# Altium schematic sheet ultrasonic_rx.SchDoc
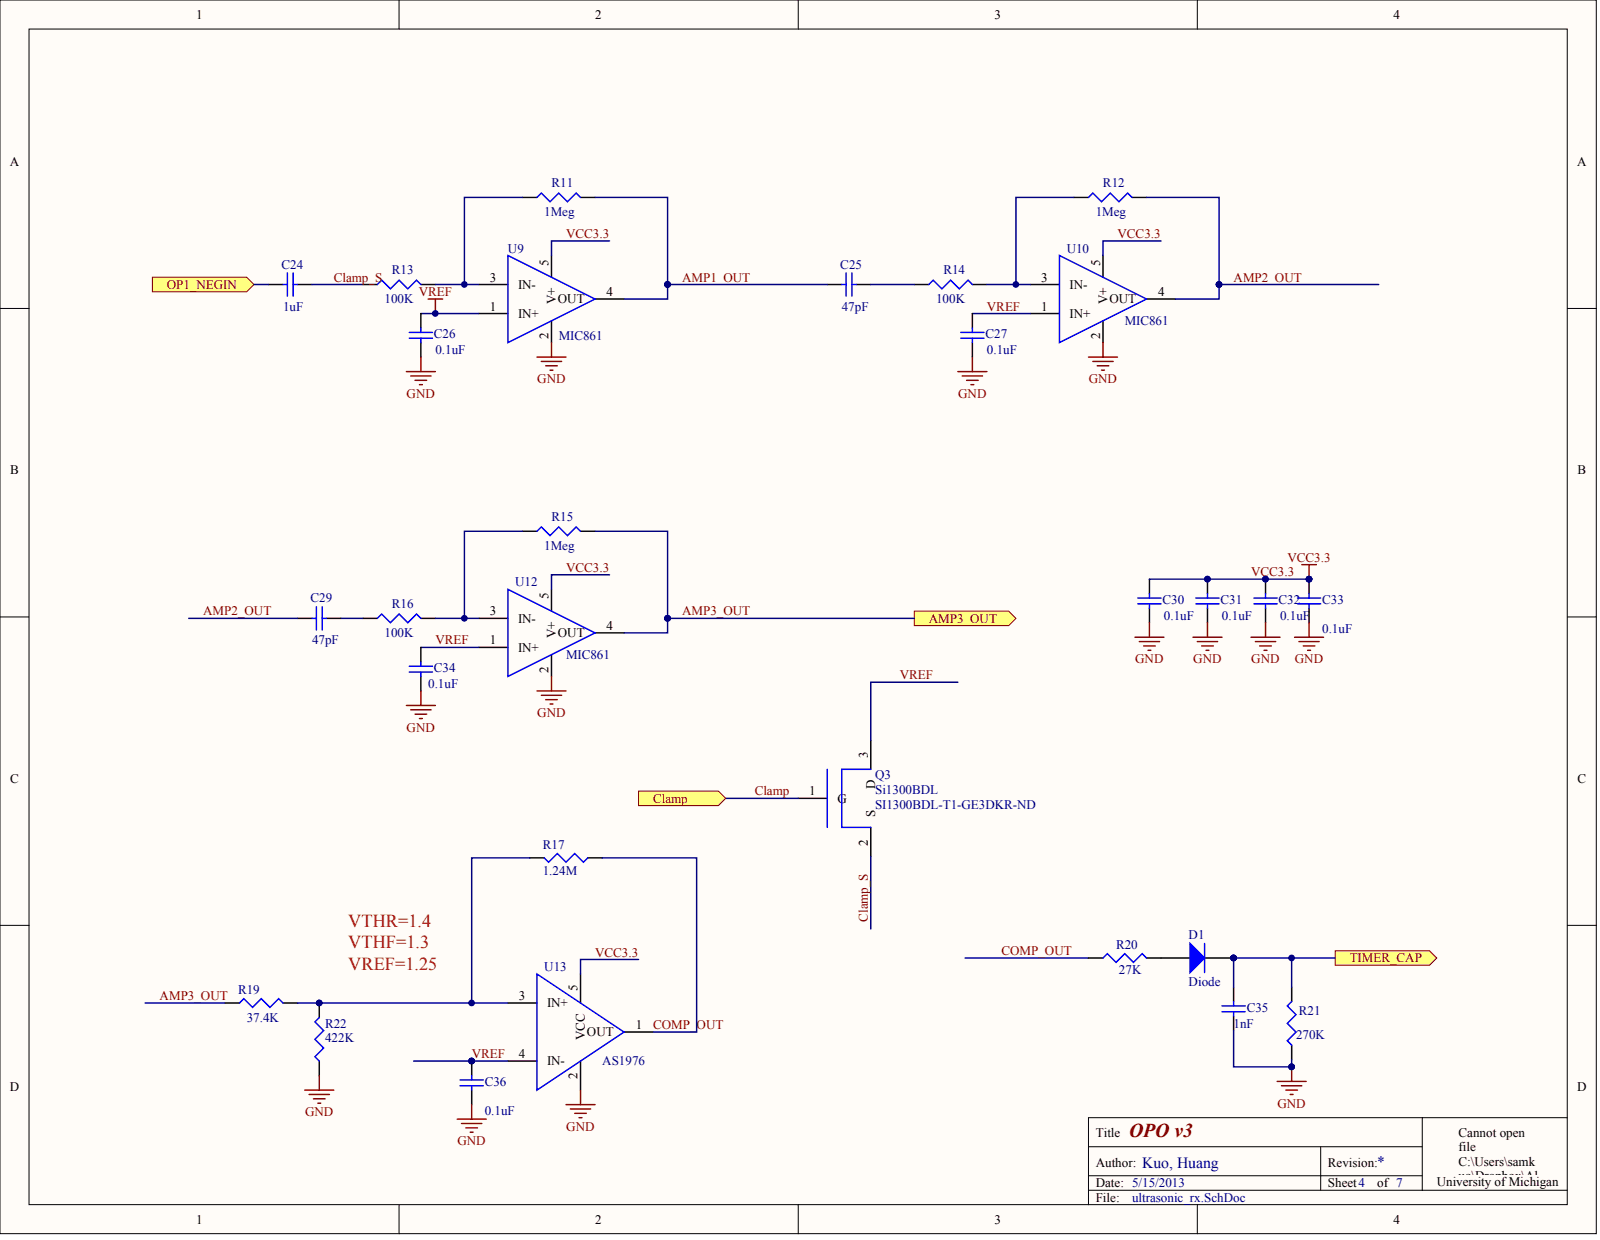
[diagram p0: ultrasonic_rx.SchDoc]

## Parts
C24  value 1uF  (hidden comment: Cap Semi)
C25  value 47pF  (hidden comment: Cap Semi)
C26  value 0.1uF  (hidden comment: Cap Semi)
C27  value 0.1uF  (hidden comment: Cap Semi)
C29  value 47pF  (hidden comment: Cap Semi)
C30  value 0.1uF  (hidden comment: Cap Semi)
C31  value 0.1uF  (hidden comment: Cap Semi)
C32  value 0.1uF  (hidden comment: Cap Semi)
C33  value 0.1uF  (hidden comment: Cap Semi)
C34  value 0.1uF  (hidden comment: Cap Semi)
C35  value 1nF  (hidden comment: Cap Semi)
C36  value 0.1uF  (hidden comment: Cap Semi)
D1  Diode
Q3  Si1300BDL  Supplier Part Number 1: SI1300BDL-T1-GE3DKR-ND
R11  1Meg
R12  1Meg
R13  100K
R14  100K
R15  1Meg
R16  100K
R17  1.24M
R19  37.4K
R20  27K
R21  270K
R22  422K
U9  MIC861
U10  MIC861
U12  MIC861
U13  AS1976

## Ports
AMP3_OUT, CLAMP, OP1_NEGIN, TIMER_CAP

## Nets
GND: C26.1 C27.1 C30.1 C31.1 C32.1 C33.1 C34.1 C35.2 C36.1 R21.1 R22.1 U9.2 U10.2 U12.2 U13.2
AMP1_OUT: C25.1 R11.2 U9.4(OUT)
AMP2_OUT: C29.1 R12.2 U10.4(OUT)
AMP3_OUT: R15.2 R19.1 U12.4(OUT) ; port AMP3_OUT
CLAMP: Q3.1 ; port CLAMP
CLAMP_S: C24.1 Q3.2 R13.1
COMP_OUT: R17.1 R20.1 U13.1(OUT)
OP1_NEGIN: C24.2 ; port OP1_NEGIN
TIMER_CAP: C35.1 D1.2 R21.2 ; port TIMER_CAP
VCC3.3: C30.2 C31.2 C32.2 C33.2 U9.5(V+) U10.5(V+) U12.5(V+) U13.5(VCC)
VREF: C26.2 C27.2 C34.2 C36.2 Q3.3 U9.1(IN+) U10.1(IN+) U12.1(IN+) U13.4(IN-)
NetC25_2: C25.2 R14.1
NetC29_2: C29.2 R16.1
NetD1_1: D1.1 R20.2
NetR11_1: R11.1 R13.2 U9.3(IN-)
NetR12_1: R12.1 R14.2 U10.3(IN-)
NetR15_1: R15.1 R16.2 U12.3(IN-)
NetR17_2: R17.2 R19.2 R22.2 U13.3(IN+)

## Library data of the parts
C24-C27 C29-C36 D1 Q3 R11-R17 R19-R22 U9 U10 U12 U13 = (no symbol name)
  C24-C27 C29-C36: Published: 8-Jun-2000; LatestRevisionDate: 29-May-2009; LatestRevisionNote: IPC-7351 Footprint Added.; PackageReference: C1206; PackageDescription: Chip Capacitor
  D1: Published: 8-Jun-2000; LatestRevisionDate: 17-Jul-2002; LatestRevisionNote: Re-released for DXP Platform.; PackageVersion: Sep-1996; PackageReference: SMC; PackageDescription: DO-214-AB/SMC; 2 C-Bend Leads; Body 7.9 x 5.9 mm, inc. leads (LxW); Code_JEDEC: DO-214-AB
  Q3: Supplier 1: Digi-Key
  R11 R12 R15: Published: 8-Jun-2000; LatestRevisionDate: 29-May-2009; LatestRevisionNote: IPC-7351 Footprint Added.; PackageReference: J1-0603; PackageDescription: Chip Resistor; Value: 1Meg
  R13 R14 R16: Published: 8-Jun-2000; LatestRevisionDate: 29-May-2009; LatestRevisionNote: IPC-7351 Footprint Added.; PackageReference: J1-0603; PackageDescription: Chip Resistor; Value: 100K
  R17: Published: 8-Jun-2000; LatestRevisionDate: 29-May-2009; LatestRevisionNote: IPC-7351 Footprint Added.; PackageReference: J1-0603; PackageDescription: Chip Resistor; Value: 1.24M
  R19: Published: 8-Jun-2000; LatestRevisionDate: 29-May-2009; LatestRevisionNote: IPC-7351 Footprint Added.; PackageReference: J1-0603; PackageDescription: Chip Resistor; Value: 37.4K
  R20: Published: 8-Jun-2000; LatestRevisionDate: 29-May-2009; LatestRevisionNote: IPC-7351 Footprint Added.; PackageReference: J1-0603; PackageDescription: Chip Resistor; Value: 27K
  R21: Published: 8-Jun-2000; LatestRevisionDate: 29-May-2009; LatestRevisionNote: IPC-7351 Footprint Added.; PackageReference: J1-0603; PackageDescription: Chip Resistor; Value: 270K
  R22: Published: 8-Jun-2000; LatestRevisionDate: 29-May-2009; LatestRevisionNote: IPC-7351 Footprint Added.; PackageReference: J1-0603; PackageDescription: Chip Resistor; Value: 422K
  U9 U10 U12: Supplier 1: Digi-Key; Supplier Part Number 1: 576-2932-1-ND
  U13: Supplier 1: Digi-Key; Supplier Part Number 1: AS1976-TCT-ND
  C24-C27 C29-C36 D1 R11-R17 R19-R22: Publisher: Altium Limited
